# Altium schematic sheet Sheet3.SchDoc(Sheet3)
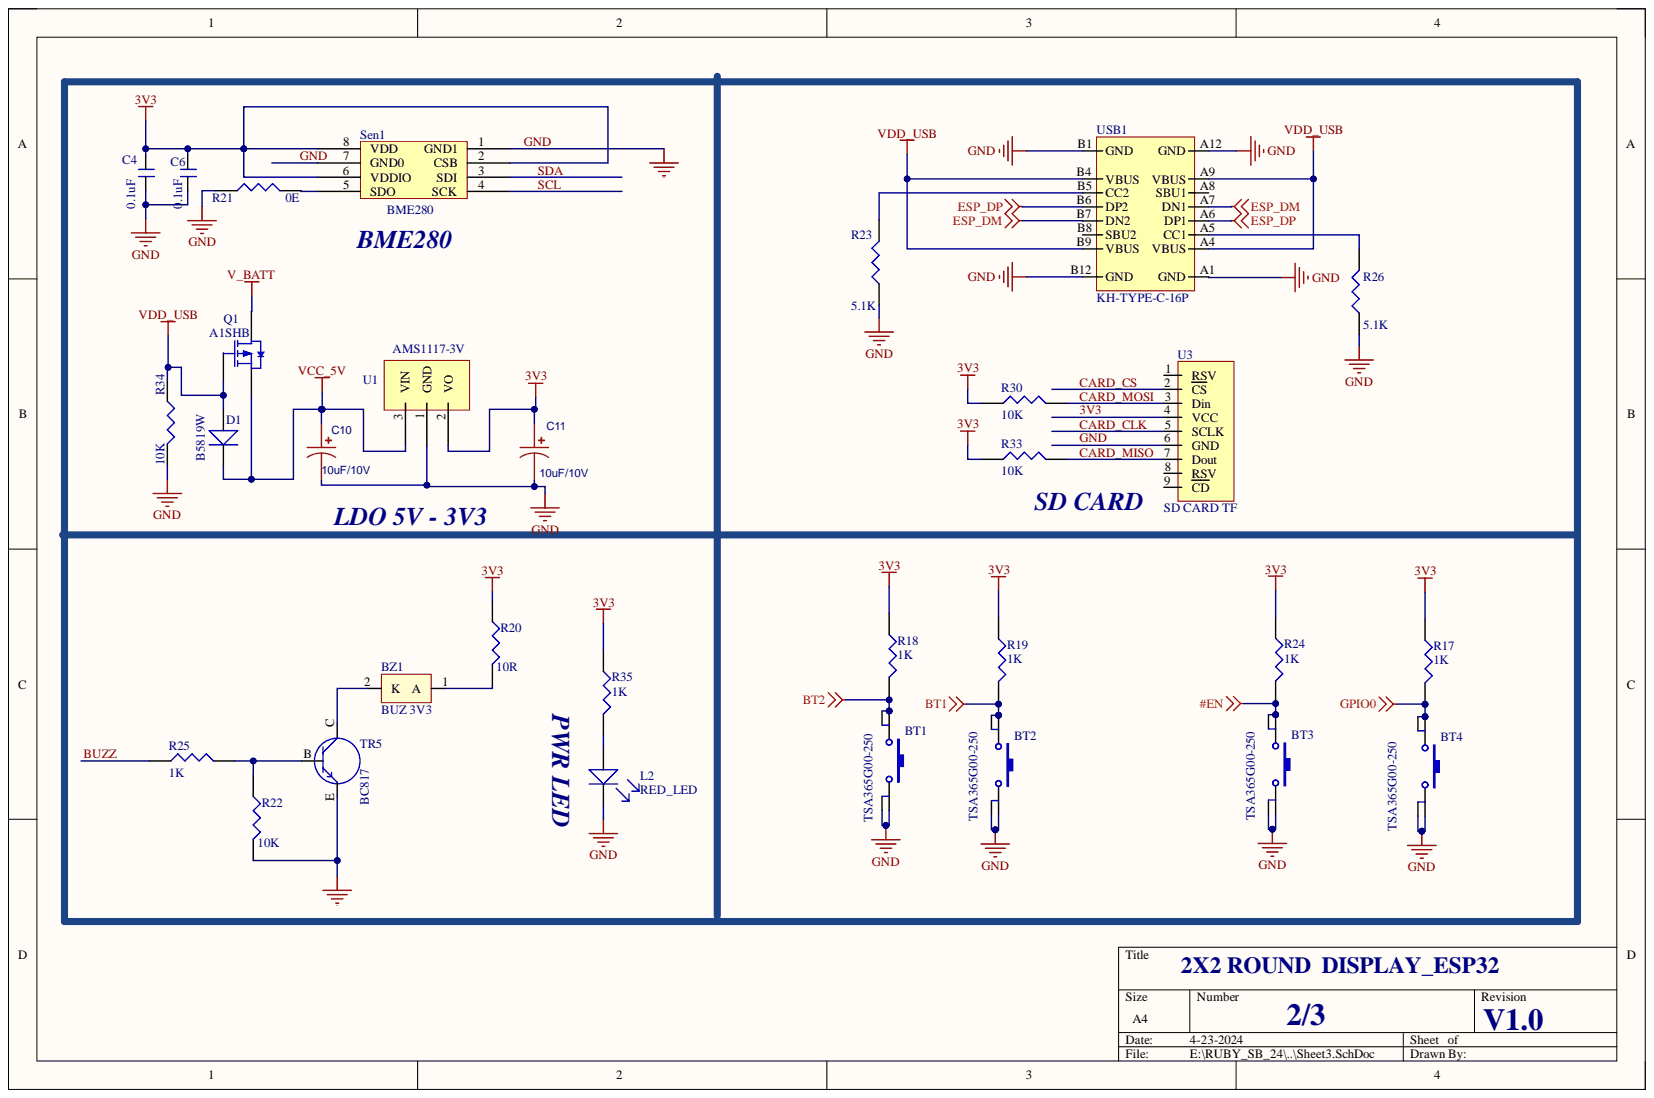
[diagram p0: Sheet3.SchDoc]

## Parts
BT1  TSA365G00-250  [TACT_]
BT2  TSA365G00-250  [TACT_]
BT3  TSA365G00-250  [TACT_]
BT4  TSA365G00-250  [TACT_]
BZ1  BUZ 3V3  [SMD BUZZ]
C4  0.1uF  [C_0603]
C6  0.1uF  [C_0603]
C10  10uF/10V  [Tantlum_A_3216]
C11  10uF/10V  [Tantlum_A_3216]
D1  B5819W  [SOD-123]
L2  RED_LED  [LED_0603]
Q1  A1SHB  [BSS84]
R17  1K  [R_0603]
R18  1K  [R_0603]
R19  1K  [R_0603]
R20  10R  [R_0603]
R21  0E  [R_0603]
R22  10K  [R_0603]
R23  5.1K  [R_0603]
R24  1K  [R_0603]
R25  1K  [R_0603]
R26  5.1K  [R_0603]
R30  10K  [R_0603]
R33  10K  [R_0603]
R34  10K  [R_0603]
R35  1K  [R_0603]
Sen1  BME280  [BME28]
TR5  BC817  [SOT23]
U1  AMS1117-3V  [AMS1117_3V3]
U3  SD CARD TF  [micro sd card]
USB1  KH-TYPE-C-16P  [USB TYPE _C]

## Nets
#EN: BT3.1 BT3.2 R24.2
BT1: BT2.1 BT2.2 R19.2
BT2: BT1.1 BT1.2 R18.2
ESP_DM: USB1.A7(DN1) USB1.B7(DN2)
ESP_DP: USB1.A6(DP1) USB1.B6(DP2)
GPIO0: BT4.1 BT4.2 R17.2
V_BATT: Q1.3
VCC_5V: C10.1 D1.K Q1.2 U1.3
VDD_USB: D1.A Q1.1 R34.1 USB1.A4(VBUS) USB1.A9(VBUS) USB1.B4(VBUS) USB1.B9(VBUS)
3V3: C4.2 C6.2 C11.1 R17.1 R18.1 R19.1 R20.1 R24.1 R30.1 R33.1 R35.1 Sen1.2(CSB) Sen1.6(VDDIO) Sen1.8(VDD) U1.2 U3.4(VCC)
BUZZ: R25.1
CARD_CLK: U3.5(SCLK)
CARD_CS: U3.2(CS)
CARD_MISO: R33.2 U3.7(Dout)
CARD_MOSI: R30.2 U3.3(Din)
GND: BT1.3 BT1.4 BT2.3 BT2.4 BT3.3 BT3.4 BT4.3 BT4.4 C4.1 C6.1 C10.2 C11.2 L2.K R21.1 R22.2 R23.1 R26.1 R34.2 Sen1.1(GND1) Sen1.7(GND0) TR5.E U1.1 U3.6(GND) USB1.A1(GND) USB1.A12(GND) USB1.B1(GND) USB1.B12(GND)
SCL: Sen1.4(SCK)
SDA: Sen1.3(SDI)
NetBZ1_1: BZ1.1 R20.2
NetBZ1_2: BZ1.2 TR5.C
NetL2_A: L2.A R35.2
NetR21_2: R21.2 Sen1.5(SDO)
NetR22_1: R22.1 R25.2 TR5.B
NetR23_2: R23.2 USB1.B5(CC2)
NetR26_2: R26.2 USB1.A5(CC1)

Not connected: U3 1(RSV) 8(RSV) 9(CD); USB1 A8(SBU1) B8(SBU2)

## Library data of the parts
BT1-BT4 = TACTILE_SWITCH: Component Kind: Standard; Library Name: dxp_pasl_lib.IntLib
BZ1 = Buzzer: Component Kind: Standard; Library Name: dxp_pasl_lib.IntLib
C4 C6 = CAP_NONPOLAR: Component Kind: Standard; Library Name: dxp_pasl_lib.IntLib
C10 C11 = 270uF: BOM_Manufacturer: rubycon(红宝石); BOM_Manufacturer Part: 100ZL270MEFC12.5X35; Contributor: lcsc; nameAlias: Capacitance; BOM_JLCPCB Part Class: Extended Part; BOM_Supplier: LCSC; BOM_Supplier Part: C1598036; Component Kind: Standard; link: https://atta.szlcsc.com/upload/public/pdf/source/20210810/D32E969E718DCFB364F518B2A745C406.pdf; spicePre: C; spiceSymbolName: 100ZL270MEFC12.5X35; Library Name: MIX.SchLib
D1 = DIODE: Component Kind: Standard; Library Name: dxp_pasl_lib.IntLib
L2 = LED: Component Kind: Standard; Library Name: dxp_pasl_lib.IntLib
Q1 = BSS84: 50V 130mA 250mW 10Ω 10V,130mA 2V 1mA P Channel SOT-23(SOT-23-3) MOSFETs ROHS #C493579; PackageDocument: 1995/1996; CATEGORY: MOSFET; Component Kind: Standard; LatestRevisionDate: 17-Jul-2002; LatestRevisionNote: Re-released for DXP Platform.; PackageReference: E3; Published: 8-Jun-2000; Library Name: dxp_pasl_lib.IntLib; Publisher: Altium Limited
R17-R26 R30 R33-R35 = RES: Component Kind: Standard; Library Name: dxp_pasl_lib.IntLib
Sen1 = BME280: Digital humidity, pressure and temperature sensor 2.5mm X 2.5mm X 0.93mm metal lid LGA; Component Kind: Standard; Library Name: dxp_pasl_lib.IntLib
TR5 = TRANSISTER_NPN: Component Kind: Standard; Library Name: dxp_pasl_lib.IntLib
U1 = AMS1117: Component Kind: Standard; Library Name: dxp_pasl_lib.IntLib
U3 = SD CARD TF: Micro SD Memory Card Socket; Component Kind: Standard; Library Name: dxp_pasl_lib.IntLib
USB1 = USB TYPE-C KH-TYPE-C-16P: 3A 1 Surface Mount 16 Female -40?~+85? Type-C SMD USB Connectors ROHS #  C709357; Component Kind: Standard; ComponentLink2Description: New link; ComponentLink2URL: https://lcsc.com/product-detail/USB-Connectors_Shenzhen-Kinghelm-Elec-KH-TYPE-C-16P_C709357.html; Supplier 1: LCSC; Supplier Part Number 1: C165948; Library Name: dxp_pasl_lib.IntLib
